# Altium schematic sheet encoder.SchDoc
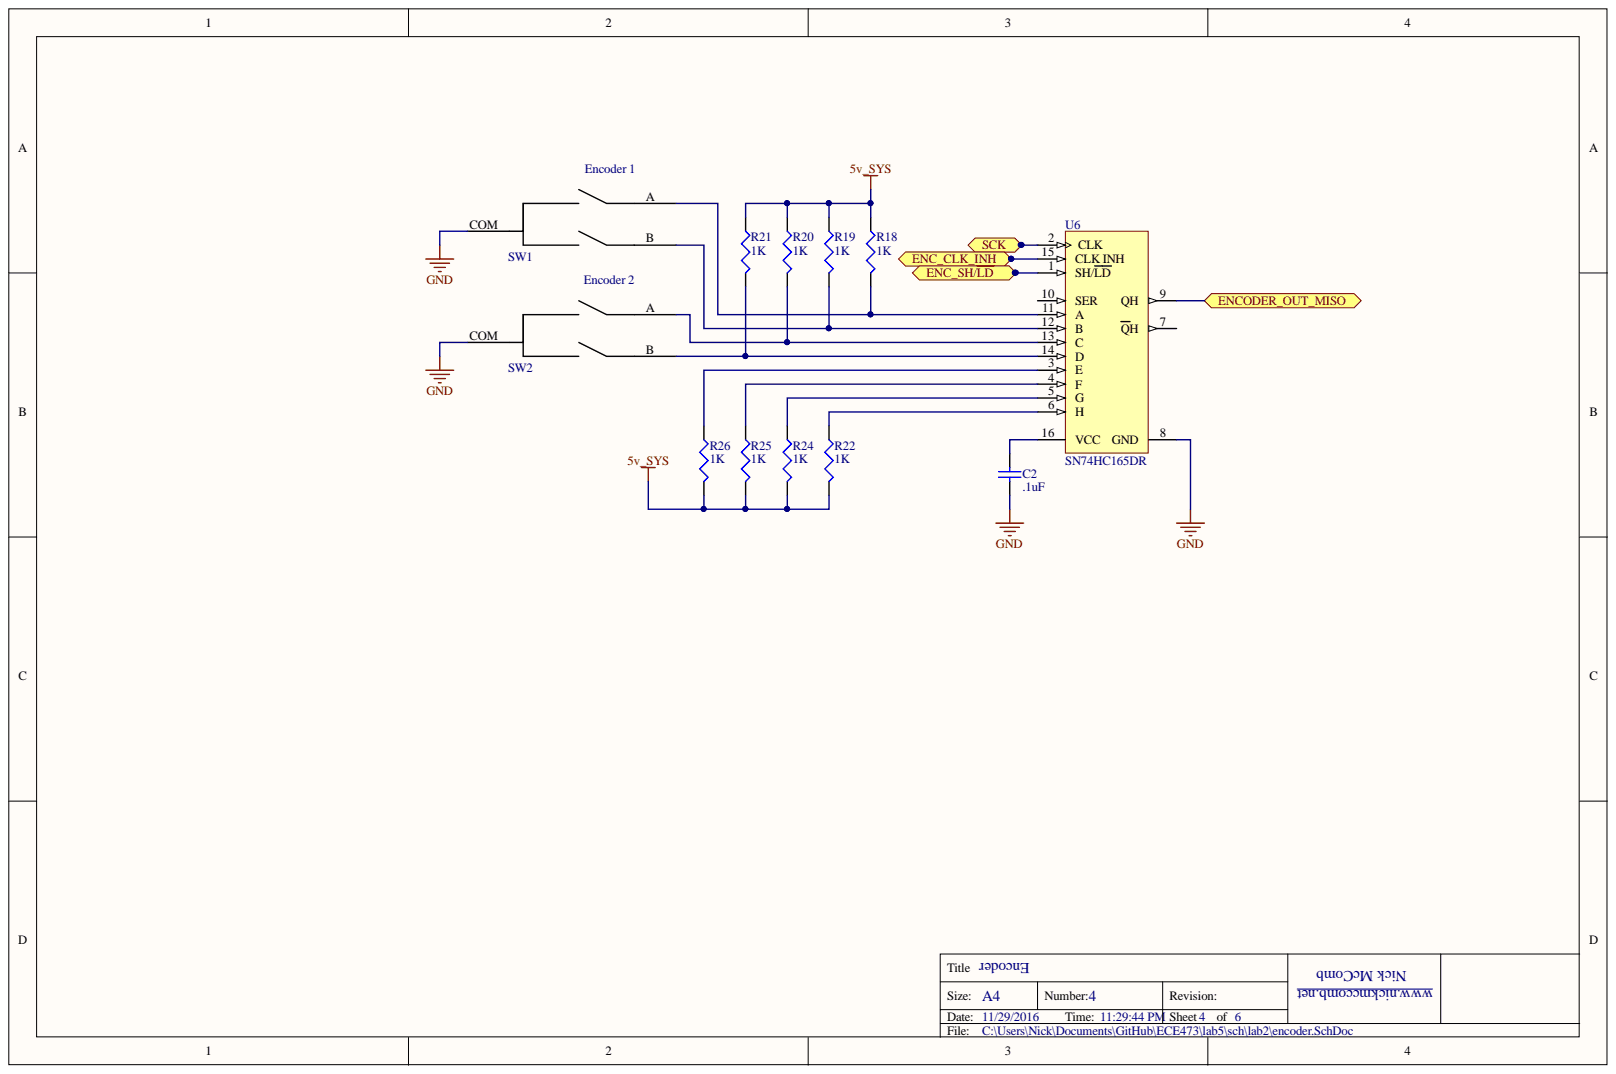
[diagram p0: encoder.SchDoc]

## Parts
C2  value .1uF  [C0805]  (hidden comment: 0805 style, by default)
R18  1K  [J1-0603]
R19  1K  [J1-0603]
R20  1K  [J1-0603]
R21  1K  [J1-0603]
R22  1K  [J1-0603]
R24  1K  [J1-0603]
R25  1K  [J1-0603]
R26  1K  [J1-0603]
SW1  ENCODER  (value not drawn)
SW2  ENCODER  (value not drawn)
U6  SN74HC165DR  [D0016A_L]

## Ports
ENC_CLK_INH, ENC_SH/~{LD}, ENCODER_OUT_MISO, SCK

## Nets
5v_SYS: R18.2 R19.2 R20.2 R21.2 R22.1 R24.1 R25.1 R26.1
GND: C2.1 SW1.COM SW2.COM U6.8(GND)
ENC_CLK_INH: U6.15(CLK_INH) ; port ENC_CLK_INH
ENC_SH/~{LD}: U6.1(SH/LD) ; port ENC_SH/~{LD}
ENCODER_OUT_MISO: U6.9(QH) ; port ENCODER_OUT_MISO
SCK: U6.2(CLK) ; port SCK
NetC2_2: C2.2 U6.16(VCC)
NetR18_1: R18.1 SW1.A U6.11(A)
NetR19_1: R19.1 SW1.B U6.12(B)
NetR20_1: R20.1 SW2.A U6.13(C)
NetR21_1: R21.1 SW2.B U6.14(D)
NetR22_2: R22.2 U6.6(H)
NetR24_2: R24.2 U6.5(G)
NetR25_2: R25.2 U6.4(F)
NetR26_2: R26.2 U6.3(E)

Not connected: U6 7(QH) 10(SER)

## Library data of the parts
C2 = Cap: Capacitor; Component Kind: Standard; LatestRevisionDate: 17-Jul-2002; LatestRevisionNote: Re-released for DXP Platform.; Library Name: lab2.SchLib; PackageDescription: Radial Cap, Thru-Hole; 2 Leads; 0.3 in Pin Spacing; PackageReference: RAD-0.3; Published: 8-Jun-2000; Signal Integrity: Cap; Simulation: CAP; Publisher: Altium Limited
R18-R22 R24-R26 = Res3: Resistor; Component Kind: Standard; LatestRevisionDate: 29-May-2009; LatestRevisionNote: IPC-7351 Footprint Added.; Library Name: lab2.SchLib; PackageDescription: Chip Resistor; PackageReference: J1-0603; Published: 8-Jun-2000; Value: 1K; Publisher: Altium Limited
U6 = CMP-0780-00020-1: 8-Bit Parallel-Load Shift Registers, D0016A, LARGE T&R; 3-State Output: No; Bits: 8; F @ Nom Voltage(Max)(Mhz): 28; ICC @ Nom Voltage(Max)(mA): 0.08; Input Type: CMOS; Output Drive (IOL/IOH)(Max)(mA): 5.2/-5.2; Rating: Catalog; Technology Family: HC; tpd @ Nom Voltage(Max)(ns): 38; VCC(Max)(V): 6; VCC(Min)(V): 2; Code_IPC: MS-012 variation AC; Component Kind: Standard; ComponentLink1Description: Manufacturer URL; ComponentLink1URL: http://www.ti.com/; ComponentLink2Description: Datasheet; ComponentLink2URL: http://www.ti.com/lit/ds/symlink/sn74hc165.pdf; DatasheetVersion: SCLS116G; Library Name: Altium Content Vault; Operating Temperature Range(C): -40 to 125; Output Type: CMOS; PackageReference: D0016A; PackageVersion: http://www.ti.com/lit/ml/mpds178g/mpds178g.pdf; Part Number: SN74HC165; PartNumber: SN74HC165DR; Voltage(Nom)(V): 6
SW1 SW2 = ENCODER: Component Kind: Standard; Library Name: lab2.SchLib
